# Altium schematic sheet Volga-PCB.SchDoc(Volga-PCB)
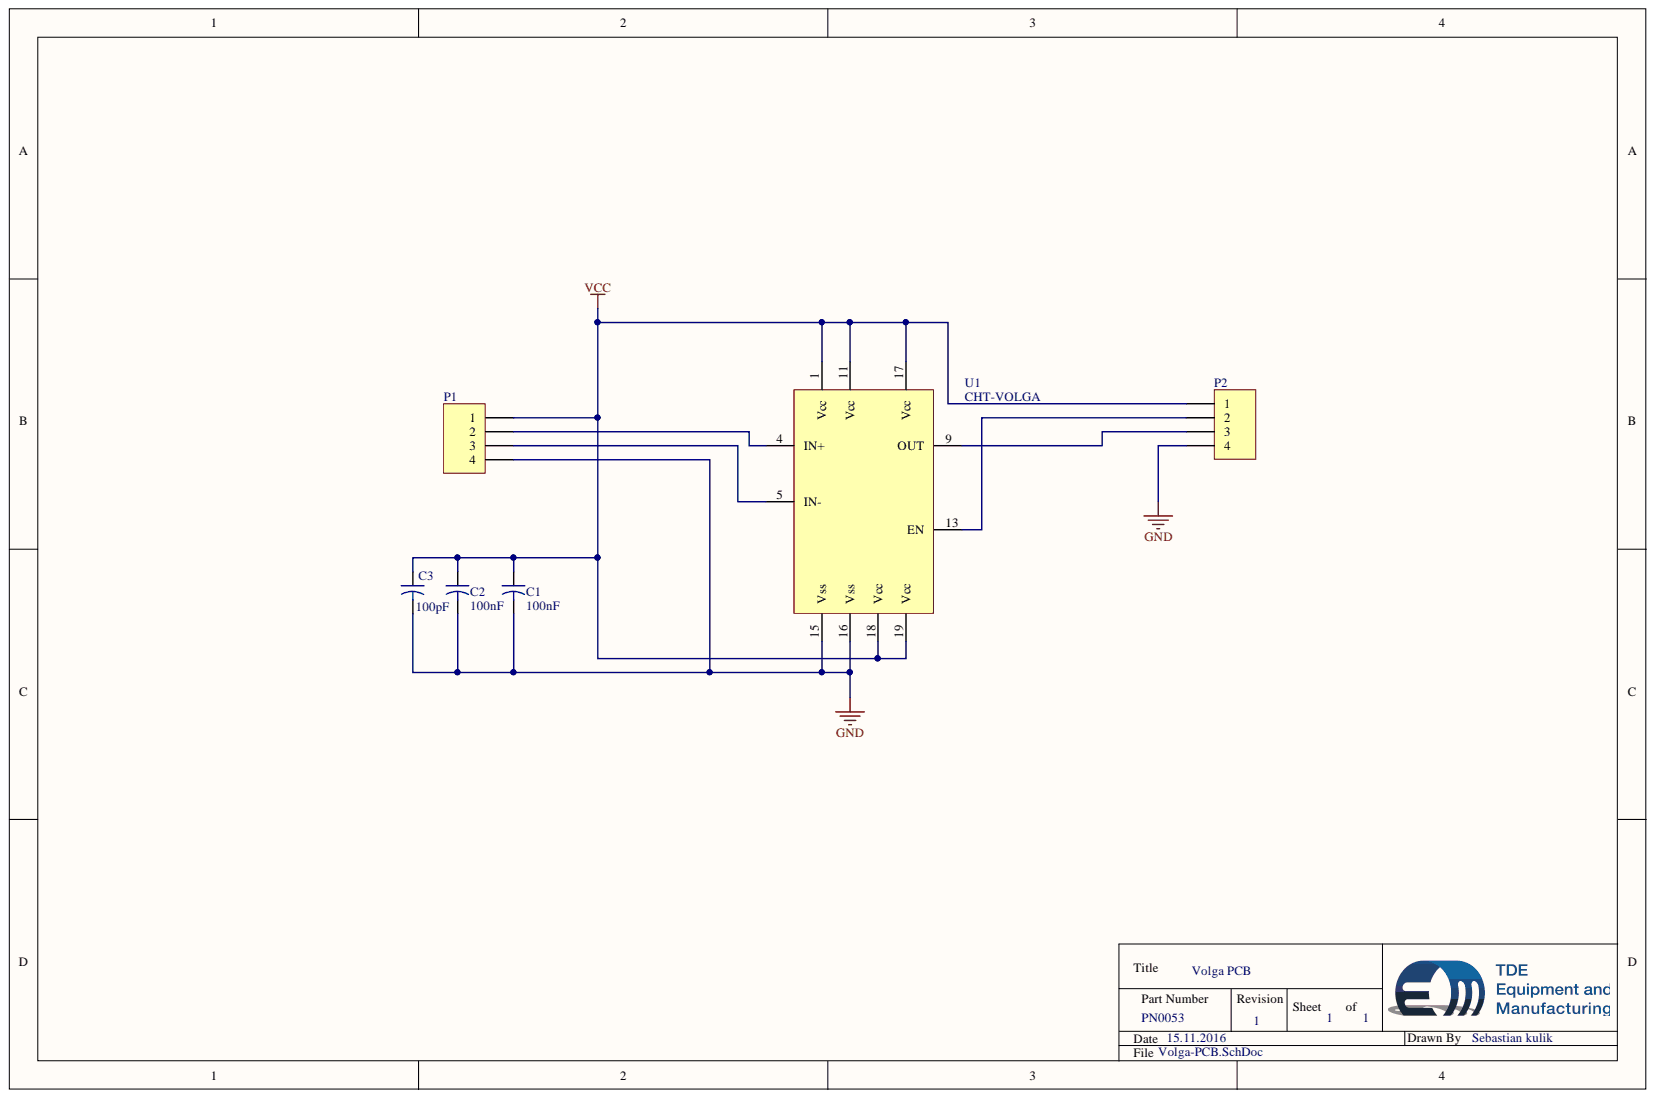
[diagram p0: Volga-PCB.SchDoc]

## Parts
C1  value 100nF  [Dummy-10mm]  (hidden comment: Capacitor-Dummy-THT)
C2  value 100nF  [Dummy-10mm]  (hidden comment: Capacitor-Dummy-THT)
C3  value 100pF  [Dummy-10mm]  (hidden comment: Capacitor-Dummy-THT)
P1  Plug_4_90_2.54  [HDR1X4]  (value not drawn)
P2  Plug_4_90_2.54  [HDR1X4]  (value not drawn)
U1  CHT-VOLGA  [TDFP16]

## Nets
GND: C1.2 C2.2 C3.2 P1.4 P2.4 U1.15(Vss) U1.16(Vss)
VCC: C1.1 C2.1 C3.1 P1.1 P2.1 U1.1(Vcc) U1.11(Vcc) U1.17(Vcc) U1.18(Vcc) U1.19(Vcc)
NetP1_2: P1.2 U1.4(IN+)
NetP1_3: P1.3 U1.5(IN-)
NetP2_2: P2.2 U1.13(EN)
NetP2_3: P2.3 U1.9(OUT)

## Library data of the parts
C1 C2 = Capacitor-Dummy-THT: Deliverer PartNr: 721-5240; Deliverer: RS; Component Kind: Standard; Description: Capacitor-Dummy-THT; Library Name: Capacitors.IntLib; Manufacturer: -; Manufacturer PartNr: -; PackageDescription: -; Part Description: Capacitor-Dummy-THT; Price: 0.081/50; Published: 08.12.2015; Signal Integrity: Cap; Simulation: CAP; TDE-PN: -; Publisher: Sebastian Kulik
C3 = Capacitor-Dummy-THT: Deliverer PartNr: 117-344; Deliverer: RS; Component Kind: Standard; Description: Capacitor-Dummy-THT; Library Name: Capacitors.IntLib; Manufacturer: -; Manufacturer PartNr: -; PackageDescription: -; Part Description: Capacitor-Dummy-THT; Price: 0.09/10; Published: 08.12.2015; Signal Integrity: Cap; Simulation: CAP; TDE-PN: -; Publisher: Sebastian Kulik
P1 P2 = Plug_4_90_2.54: Plug, 4-Pin; Deliverer PartNr: 613 004 111 21; Deliverer: WE; TDE-PN: CON0033; Component Kind: Standard; LatestRevisionDate: 06.11.2014; LatestRevisionNote: Re-released for DXP Platform.; Library Name: Connectors.IntLib; Manufacturer: WE; Manufacturer PartNr: 613 004 111 21; Signal Integrity: Connector; Publisher: Sebastian Kulik
U1 = CHT-VOLGA: Comparator, Hi-Temp, 25MHz; Deliverer: CISSOID; TDE-PN: IC0080; Component Kind: Standard; Deliverer PartNr: CHT-VOLGA; Library Name: ICs.IntLib; Manufacturer: CISSOID; Manufacturer PartNr: CHT-VOLGA; PackageDescription: DIP16; Price: 204.93/5; Published: 01.08.2016; Publisher: Sebastian Kulik
